# Altium schematic sheet DITEC-MEGA.SchDoc
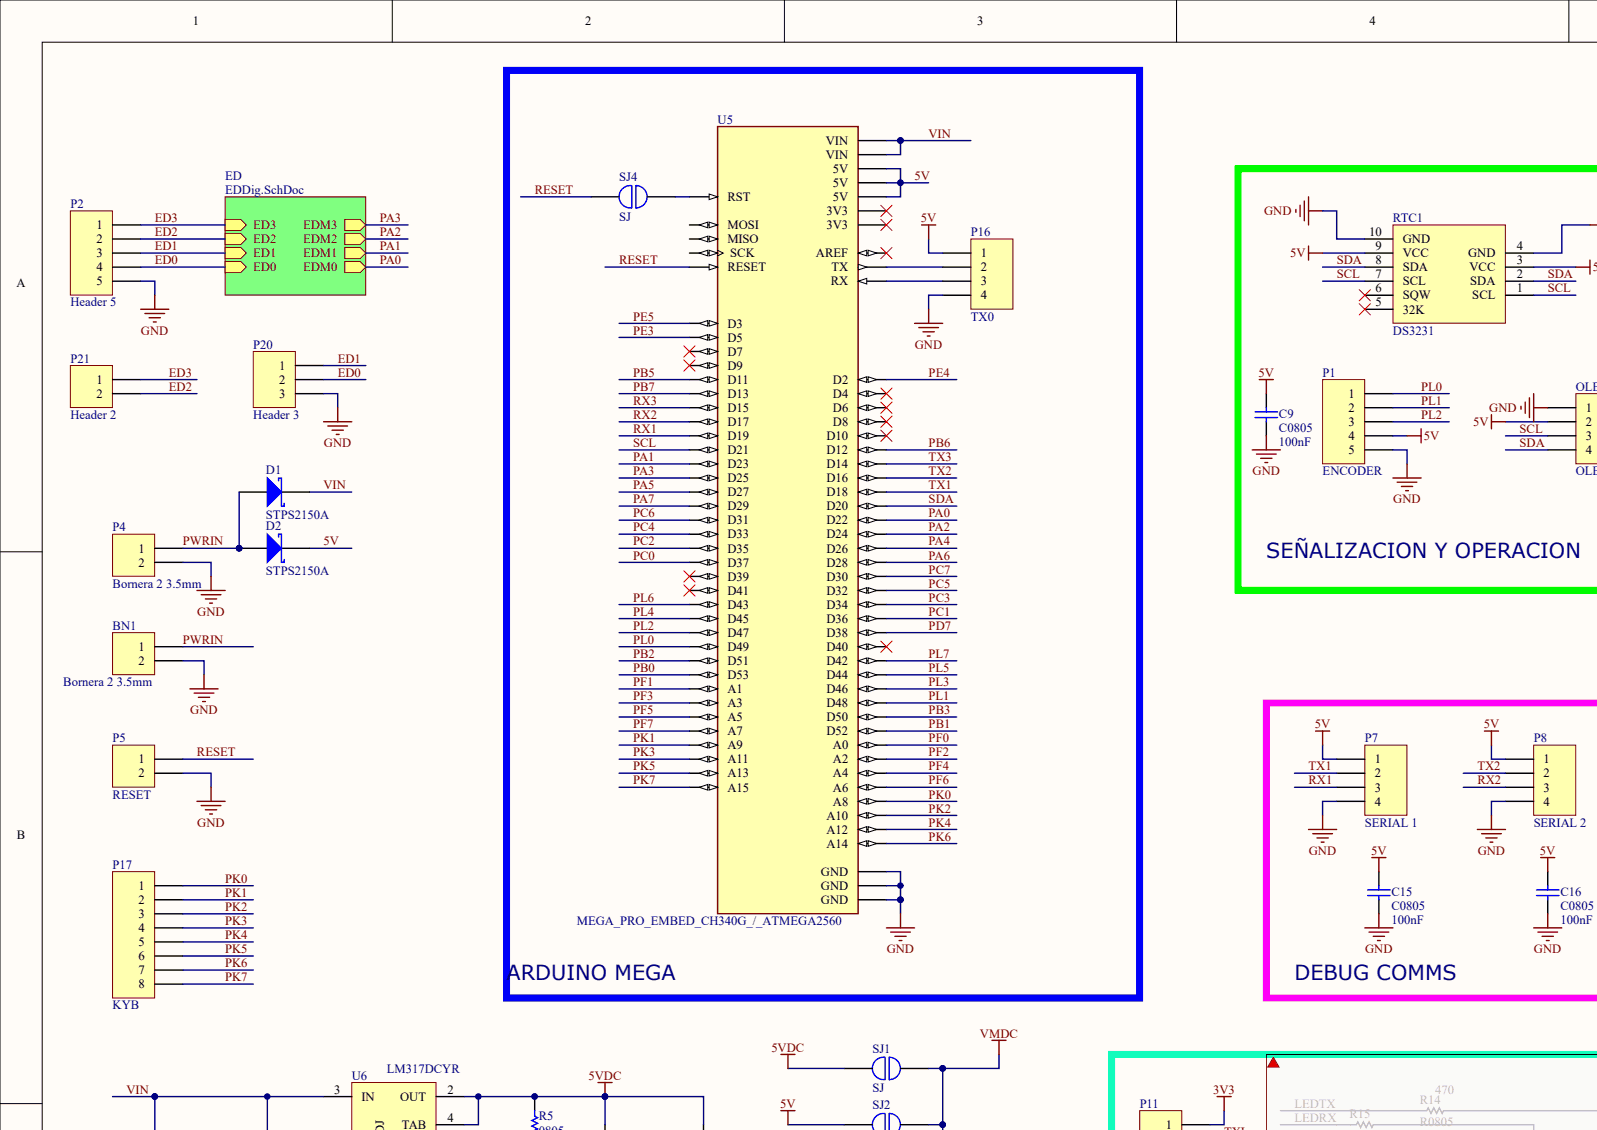
[diagram p0: DITEC-MEGA.SchDoc, top left]
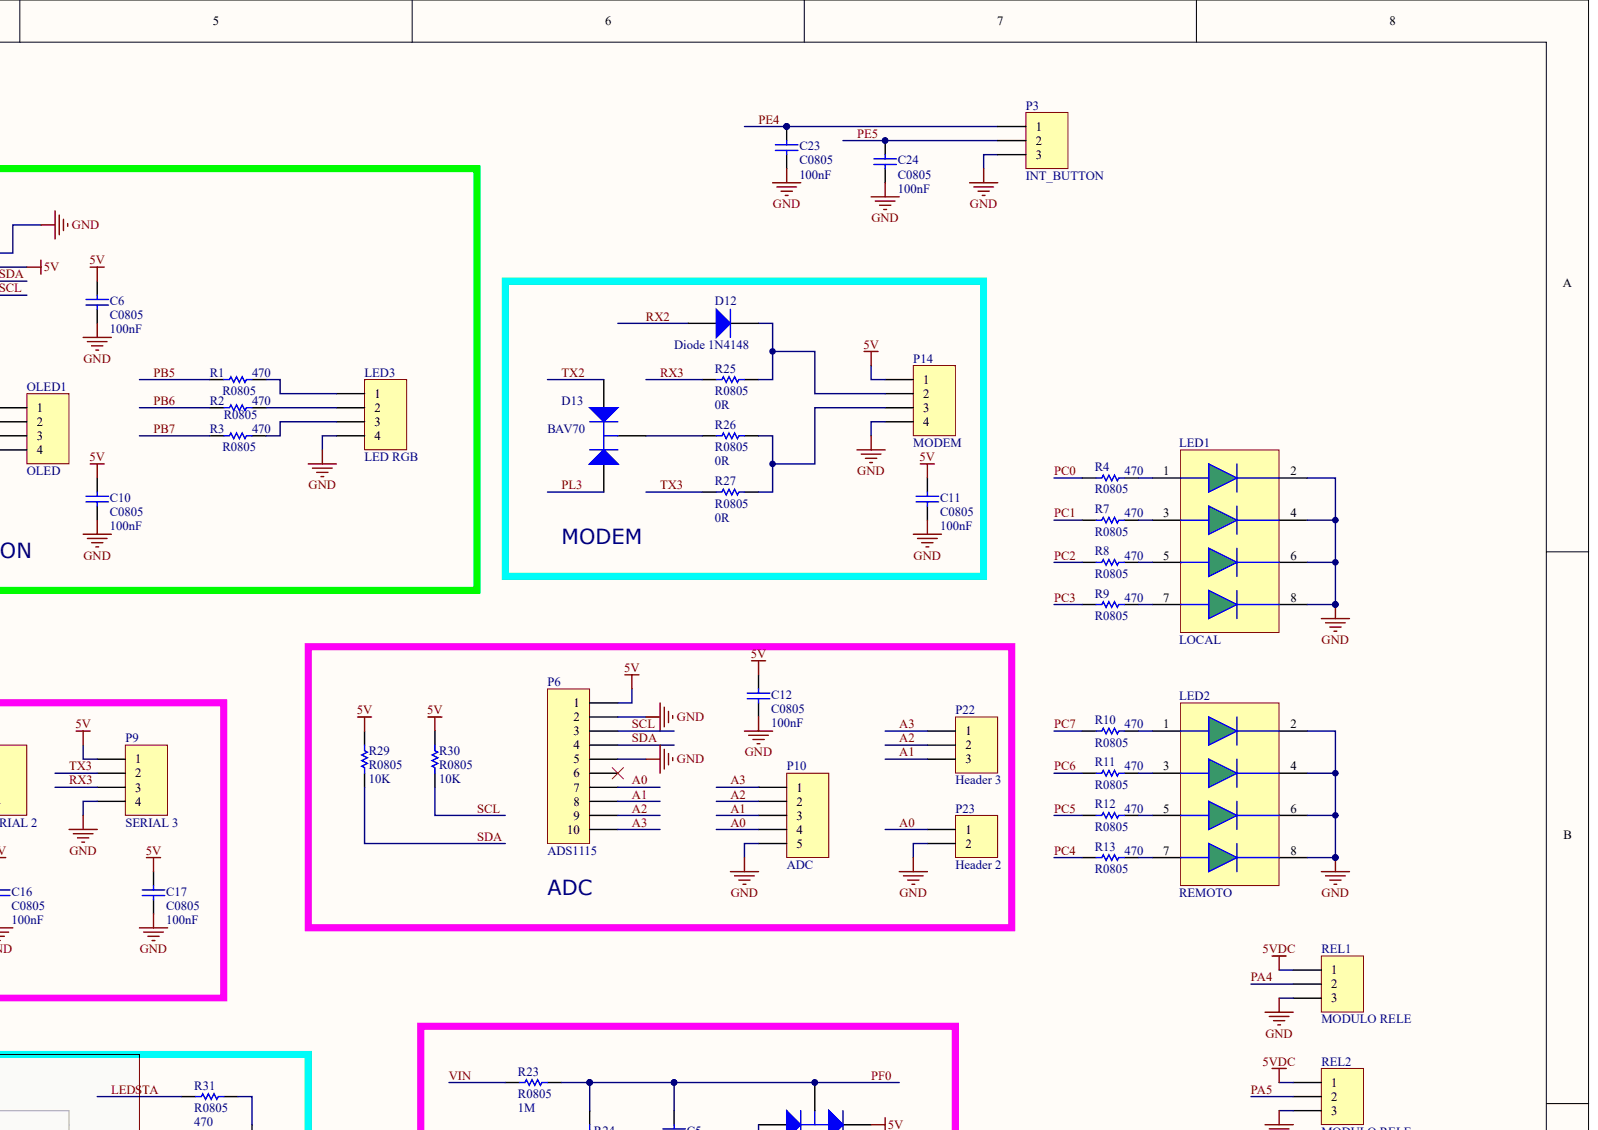
[diagram p1: DITEC-MEGA.SchDoc, top right]
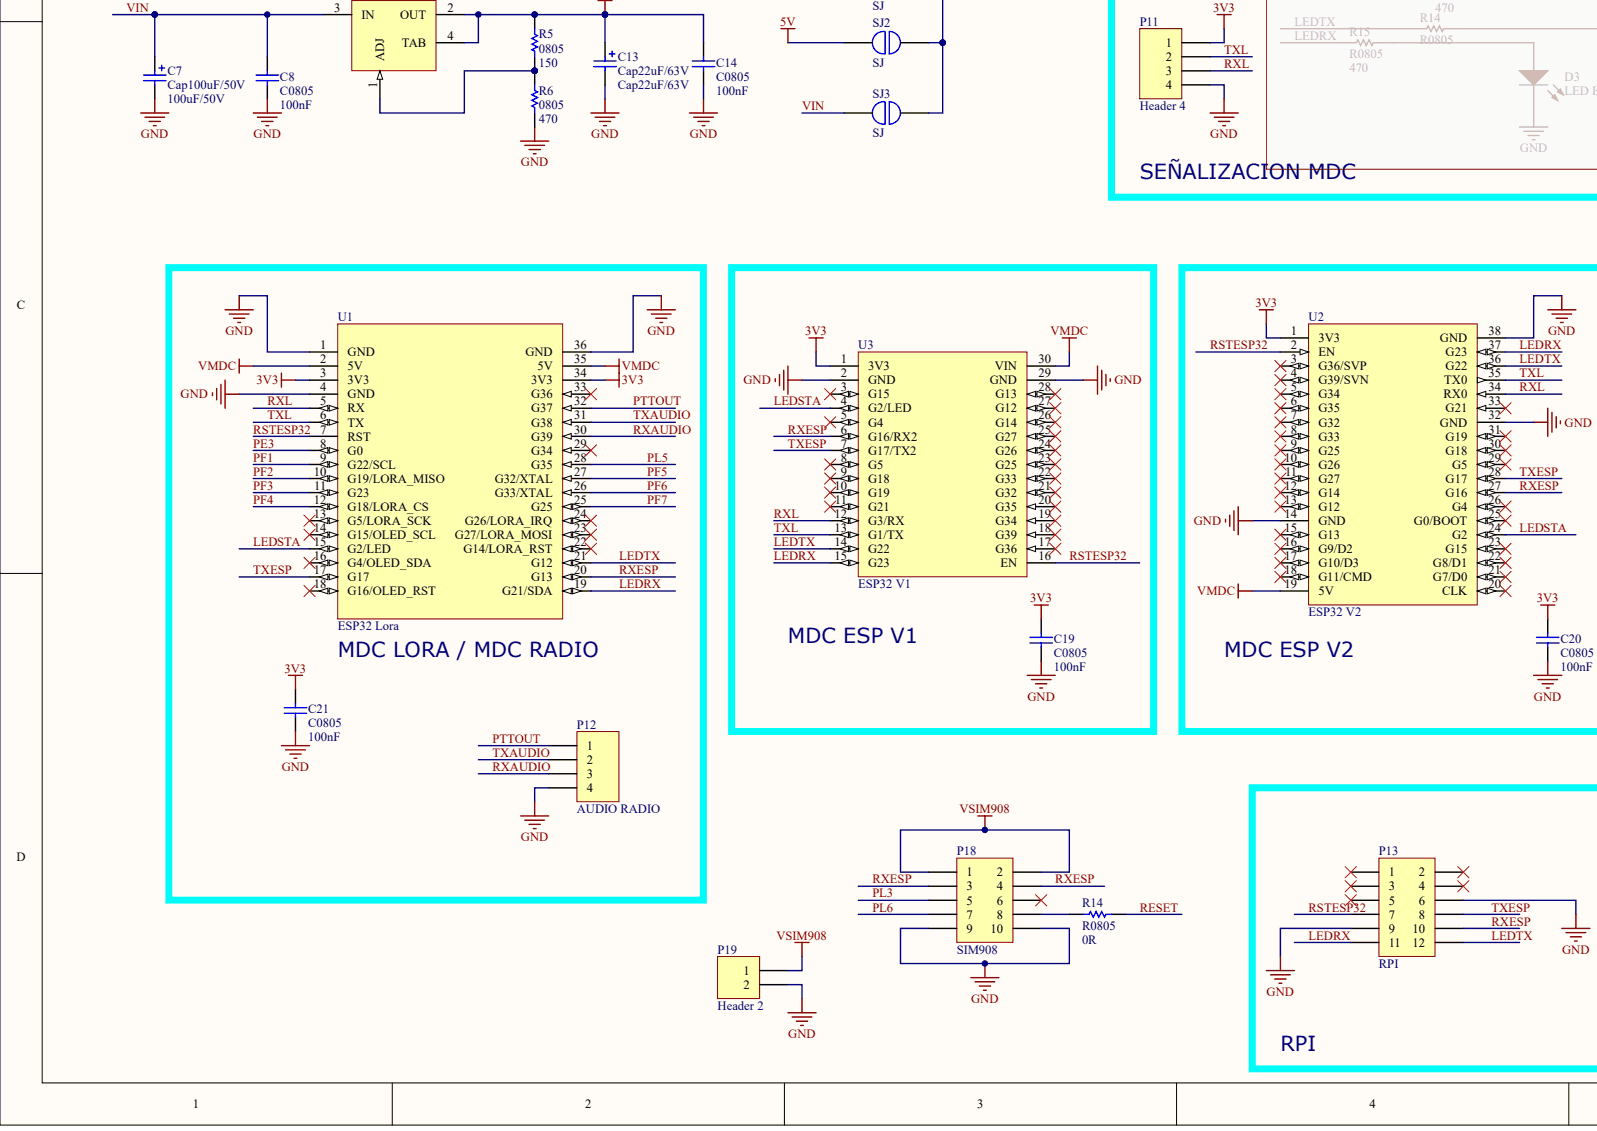
[diagram p2: DITEC-MEGA.SchDoc, bottom left]
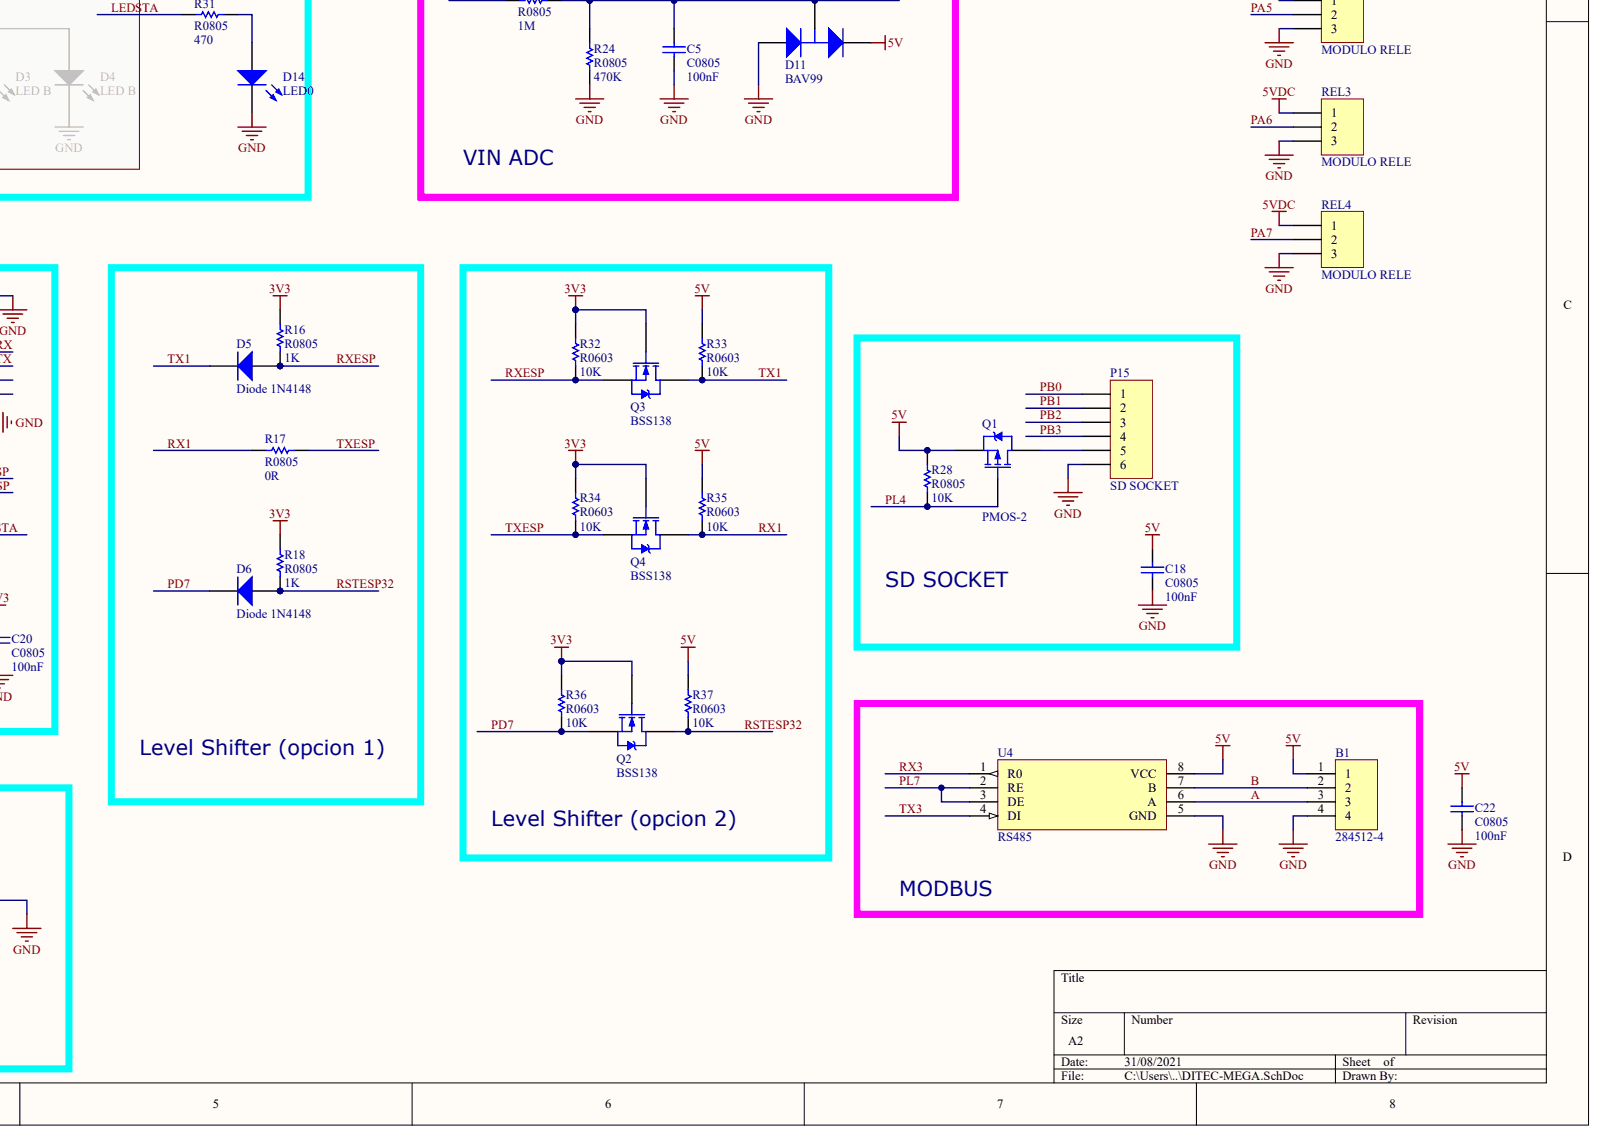
[diagram p3: DITEC-MEGA.SchDoc, bottom right]

## Parts
B1  284512-4
BN1  Bornera 2 3.5mm
C5  C0805  value 100nF
C6  C0805  value 100nF
C7  Cap100uF/50V  value 100uF/50V
C8  C0805  value 100nF
C9  C0805  value 100nF
C10  C0805  value 100nF
C11  C0805  value 100nF
C12  C0805  value 100nF
C13  Cap22uF/63V
C14  C0805  value 100nF
C15  C0805  value 100nF
C16  C0805  value 100nF
C17  C0805  value 100nF
C18  C0805  value 100nF
C19  C0805  value 100nF
C20  C0805  value 100nF
C21  C0805  value 100nF
C22  C0805  value 100nF
C23  C0805  value 100nF
C24  C0805  value 100nF
D1  STPS2150A
D2  STPS2150A
D5  Diode 1N4148
D6  Diode 1N4148
D11  BAV99
D12  Diode 1N4148
D13  BAV70
D14  LED0
LED1  LOCAL
LED2  REMOTO
LED3  LED RGB
OLED1  OLED
P1  ENCODER
P2  Header 5
P3  INT_BUTTON
P4  Bornera 2 3.5mm
P5  RESET
P6  ADS1115
P7  SERIAL 1
P8  SERIAL 2
P9  SERIAL 3
P10  ADC
P11  Header 4
P12  AUDIO RADIO
P13  RPI
P14  MODEM
P15  SD SOCKET
P16  TX0
P17  KYB
P18  SIM908
P19  Header 2
P20  Header 3
P21  Header 2
P22  Header 3
P23  Header 2
Q1  PMOS-2
Q2  BSS138
Q3  BSS138
Q4  BSS138
R1  R0805  value 470
R2  R0805  value 470
R3  R0805  value 470
R4  R0805  value 470
R5  0805  value 150
R6  0805  value 470
R7  R0805  value 470
R8  R0805  value 470
R9  R0805  value 470
R10  R0805  value 470
R11  R0805  value 470
R12  R0805  value 470
R13  R0805  value 470
R14  R0805  value 0R
R16  R0805  value 1K
R17  R0805  value 0R
R18  R0805  value 1K
R23  R0805  value 1M
R24  R0805  value 470K
R25  R0805  value 0R
R26  R0805  value 0R
R27  R0805  value 0R
R28  R0805  value 10K
R29  R0805  value 10K
R30  R0805  value 10K
R31  R0805  value 470
R32  R0603  value 10K
R33  R0603  value 10K
R34  R0603  value 10K
R35  R0603  value 10K
R36  R0603  value 10K
R37  R0603  value 10K
REL1  MODULO RELE
REL2  MODULO RELE
REL3  MODULO RELE
REL4  MODULO RELE
RTC1  DS3231
SJ1  SJ
SJ2  SJ
SJ3  SJ
SJ4  SJ
U1  ESP32 Lora
U2  ESP32 V2
U3  ESP32 V1
U4  RS485
U5  MEGA_PRO_EMBED_CH340G_/_ATMEGA2560
U6  LM317DCYR

## Nets
3V3: C19.2 C20.2 C21.2 P11.1 Q2.1 Q3.1 Q4.1 R16.2 R18.2 R32.2 R34.2 R36.2 U1.3(3V3) U1.34(3V3) U2.1(3V3) U3.1(3V3)
5VDC: C13.1 C14.2 R5.2 REL1.1 REL2.1 REL3.1 REL4.1 SJ1.1 U6.2(OUT) U6.4(TAB)
GND: B1.4 BN1.2 C5.1 C6.1 C7.2 C8.1 C9.1 C10.1 C11.1 C12.1 C13.2 C14.1 C15.1 C16.1 C17.1 C18.1 C19.1 C20.1 C21.1 C22.1 C23.1 C24.1 D11.1 D14.2 LED1.2 LED1.4 LED1.6 LED1.8 LED2.2 LED2.4 LED2.6 LED2.8 LED3.4 OLED1.1 P1.5 P2.5 P3.3 P4.2 P5.2 P6.2 P6.5 P7.4 P8.4 P9.4 P10.5 P11.4 P12.4 P13.6 P13.9 P14.4 P15.6 P16.4 P18.9 P18.10 P19.2 P20.3 P23.2 R6.1 R24.2 REL1.3 REL2.3 REL3.3 REL4.3 RTC1.4(GND) RTC1.10(GND) U1.1(GND) U1.4(GND) U1.36(GND) U2.14(GND) U2.32(GND) U2.38(GND) U3.2(GND) U3.29(GND) U4.5(GND) U5.GND_1(GND) U5.GND_2(GND) U5.GND_3(GND)
VMDC: SJ1.2 SJ2.2 SJ3.2 U1.2(5V) U1.35(5V) U2.19(5V) U3.30(VIN)
VSIM908: P18.1 P18.2 P19.1
5V: B1.1 C6.2 C9.2 C10.2 C11.2 C12.2 C15.2 C16.2 C17.2 C18.2 C22.2 D2.2 D11.2 OLED1.2 P1.4 P6.1 P7.1 P8.1 P9.1 P14.1 P16.1 Q1.3 R28.2 R29.2 R30.2 R33.2 R35.2 R37.2 RTC1.3(VCC) RTC1.9(VCC) SJ2.1 U4.8(VCC) U5.5V_1(5V) U5.5V_2(5V) U5.5V_3(5V)
A: B1.3 U4.6(A)
A0: P6.7 P10.4 P23.1
A1: P6.8 P10.3 P22.3
A2: P6.9 P10.2 P22.2
A3: P6.10 P10.1 P22.1
B: B1.2 U4.7(B)
ED0: P2.4 P20.2
ED1: P2.3 P20.1
ED2: P2.2 P21.2
ED3: P2.1 P21.1
LEDRX: P13.11 U1.19(G21/SDA) U2.37(G23) U3.15(G23)
LEDSTA: R31.1 U1.15(G2/LED) U2.24(G2) U3.4(G2/LED)
LEDTX: P13.12 U1.21(G12) U2.36(G22) U3.14(G22)
PA0: U5.D22
PA1: U5.D23
PA2: U5.D24
PA3: U5.D25
PA4: REL1.2 U5.D26
PA5: REL2.2 U5.D27
PA6: REL3.2 U5.D28
PA7: REL4.2 U5.D29
PB0: P15.1 U5.D53
PB1: P15.2 U5.D52
PB2: P15.3 U5.D51
PB3: P15.4 U5.D50
PB5: R1.1 U5.D11
PB6: R2.1 U5.D12
PB7: R3.1 U5.D13
PC0: R4.1 U5.D37
PC1: R7.1 U5.D36
PC2: R8.1 U5.D35
PC3: R9.1 U5.D34
PC4: R13.1 U5.D33
PC5: R12.1 U5.D32
PC6: R11.1 U5.D31
PC7: R10.1 U5.D30
PD7: D6.2 Q2.3 R36.1 U5.D38
PE3: U1.8(G0) U5.D5
PE4: C23.2 P3.1 U5.D2
PE5: C24.2 P3.2 U5.D3
PF0: C5.2 D11.3 R23.2 R24.1 U5.A0
PF1: U1.9(G22/SCL) U5.A1
PF2: U1.10(G19/LORA_MISO) U5.A2
PF3: U1.11(G23) U5.A3
PF4: U1.12(G18/LORA_CS) U5.A4
PF5: U1.27(G32/XTAL) U5.A5
PF6: U1.26(G33/XTAL) U5.A6
PF7: U1.25(G25) U5.A7
PK0: P17.1 U5.A8
PK1: P17.2 U5.A9
PK2: P17.3 U5.A10
PK3: P17.4 U5.A11
PK4: P17.5 U5.A12
PK5: P17.6 U5.A13
PK6: P17.7 U5.A14
PK7: P17.8 U5.A15
PL0: P1.1 U5.D49
PL1: P1.2 U5.D48
PL2: P1.3 U5.D47
PL3: D13.2 P18.5 U5.D46
PL4: Q1.1 R28.1 U5.D45
PL5: U1.28(G35) U5.D44
PL6: P18.7 U5.D43
PL7: U4.2(RE) U4.3(DE) U5.D42
PTTOUT: P12.1 U1.32(G37)
PWRIN: BN1.1 D1.1 D2.1 P4.1
RESET: P5.1 R14.2 SJ4.1 U5.RESET
RSTESP32: D6.1 P13.7 Q2.2 R18.1 R37.1 U1.7(RST) U2.2(EN) U3.16(EN)
RX1: P7.3 Q4.2 R17.1 R35.1 U5.D19
RX2: D12.1 P8.3 U5.D17
RX3: P9.3 R25.1 U4.1(R0) U5.D15
RXAUDIO: P12.3 U1.30(G39)
RXESP: D5.1 P13.10 P18.3 P18.4 Q3.3 R16.1 R32.1 U1.20(G13) U2.27(G16) U3.6(G16/RX2)
RXL: P11.3 U1.5(RX) U2.34(RX0) U3.12(G3/RX)
SCL: OLED1.3 P6.3 R30.1 RTC1.1(SCL) RTC1.7(SCL) U5.D21
SDA: OLED1.4 P6.4 R29.1 RTC1.2(SDA) RTC1.8(SDA) U5.D20
TX1: D5.2 P7.2 Q3.2 R33.1 U5.D18
TX2: D13.1 P8.2 U5.D16
TX3: P9.2 R27.1 U4.4(DI) U5.D14
TXAUDIO: P12.2 U1.31(G38)
TXESP: P13.8 Q4.3 R17.2 R34.1 U1.17(G17) U2.28(G17) U3.7(G17/TX2)
TXL: P11.2 U1.6(TX) U2.35(TX0) U3.13(G1/TX)
VIN: C7.1 C8.2 D1.2 R23.1 SJ3.1 U5.VIN_1(VIN) U5.VIN_2(VIN) U6.3(IN)
NetD12_2: D12.2 P14.2 R25.2
NetD13_3: D13.3 R26.1
NetD14_1: D14.1 R31.2
NetLED1_1: LED1.1 R4.2
NetLED1_3: LED1.3 R7.2
NetLED1_5: LED1.5 R8.2
NetLED1_7: LED1.7 R9.2
NetLED2_1: LED2.1 R10.2
NetLED2_3: LED2.3 R11.2
NetLED2_5: LED2.5 R12.2
NetLED2_7: LED2.7 R13.2
NetLED3_1: LED3.1 R1.2
NetLED3_2: LED3.2 R2.2
NetLED3_3: LED3.3 R3.2
NetP6_6: P6.6
NetP13_1: P13.1
NetP13_2: P13.2
NetP13_3: P13.3
NetP13_4: P13.4
NetP13_5: P13.5
NetP14_3: P14.3 R26.2 R27.2
NetP15_5: P15.5 Q1.2
NetP16_2: P16.2 U5.TX
NetP16_3: P16.3 U5.RX
NetP18_6: P18.6
NetP18_8: P18.8 R14.1
NetR5_1: R5.1 R6.2 U6.1(ADJ)
NetRTC1_5: RTC1.5(32K)
NetRTC1_6: RTC1.6(SQW)
NetSJ4_2: SJ4.2 U5.RST
NetU1_13: U1.13(G5/LORA_SCK)
NetU1_14: U1.14(G15/OLED_SCL)
NetU1_16: U1.16(G4/OLED_SDA)
NetU1_18: U1.18(G16/OLED_RST)
NetU1_22: U1.22(G14/LORA_RST)
NetU1_23: U1.23(G27/LORA_MOSI)
NetU1_24: U1.24(G26/LORA_IRQ)
NetU1_29: U1.29(G34)
NetU1_33: U1.33(G36)
NetU2_3: U2.3(G36/SVP)
NetU2_4: U2.4(G39/SVN)
NetU2_5: U2.5(G34)
NetU2_6: U2.6(G35)
NetU2_7: U2.7(G32)
NetU2_8: U2.8(G33)
NetU2_9: U2.9(G25)
NetU2_10: U2.10(G26)
NetU2_11: U2.11(G27)
NetU2_12: U2.12(G14)
NetU2_13: U2.13(G12)
NetU2_15: U2.15(G13)
NetU2_16: U2.16(G9/D2)
NetU2_17: U2.17(G10/D3)
NetU2_18: U2.18(G11/CMD)
NetU2_20: U2.20(CLK)
NetU2_21: U2.21(G7/D0)
NetU2_22: U2.22(G8/D1)
NetU2_23: U2.23(G15)
NetU2_25: U2.25(G0/BOOT)
NetU2_26: U2.26(G4)
NetU2_29: U2.29(G5)
NetU2_30: U2.30(G18)
NetU2_31: U2.31(G19)
NetU2_33: U2.33(G21)
NetU3_3: U3.3(G15)
NetU3_5: U3.5(G4)
NetU3_8: U3.8(G5)
NetU3_9: U3.9(G18)
NetU3_10: U3.10(G19)
NetU3_11: U3.11(G21)
NetU3_17: U3.17(G36)
NetU3_18: U3.18(G39)
NetU3_19: U3.19(G34)
NetU3_20: U3.20(G35)
NetU3_21: U3.21(G32)
NetU3_22: U3.22(G33)
NetU3_23: U3.23(G25)
NetU3_24: U3.24(G26)
NetU3_25: U3.25(G27)
NetU3_26: U3.26(G14)
NetU3_27: U3.27(G12)
NetU3_28: U3.28(G13)
NetU5_3V3_1: U5.3V3_1(3V3)
NetU5_3V3_2: U5.3V3_2(3V3)
NetU5_AREF: U5.AREF
NetU5_D4: U5.D4
NetU5_D6: U5.D6
NetU5_D7: U5.D7
NetU5_D8: U5.D8
NetU5_D9: U5.D9
NetU5_D10: U5.D10
NetU5_D39: U5.D39
NetU5_D40: U5.D40
NetU5_D41: U5.D41
NetU5_MISO: U5.MISO
NetU5_MOSI: U5.MOSI
NetU5_SCK: U5.SCK

## Library data of the parts
B1 = (no symbol name): Part: A98398-ND; Proveedor: Digikey; LatestRevisionDate: 17-Jul-2002; LatestRevisionNote: Re-released for DXP Platform.; : 0.64/125; Price: 0.15; Publisher: Altium Limited
BN1 P4 = (no symbol name): Part: A98396-ND; Proveedor: Digikey; LatestRevisionDate: 17-Jul-2002; LatestRevisionNote: Re-released for DXP Platform.; Price: 0.08; Publisher: Altium Limited
C5 C6 C8-C12 C14-C24 = (no symbol name): Part: 399-1170-1-ND; Proveedor: Digikey; Published: 8-Jun-2000; LatestRevisionDate: 17-Jul-2002; LatestRevisionNote: Re-released for DXP Platform.; PackageReference: C1206; Price: 0.10; Publisher: Altium Limited
C7 = (no symbol name): Part: P5182-ND; Published: 8-Jun-2000; LatestRevisionDate: 17-Jul-2002; LatestRevisionNote: Re-released for DXP Platform.; PackageReference: RB7.6-15; Price: 0.33; Publisher: Altium Limited
C13 = (no symbol name): Part: P5190-ND; Proveedor: Digikey; Published: 8-Jun-2000; LatestRevisionDate: 17-Jul-2002; LatestRevisionNote: Re-released for DXP Platform.; PackageReference: RB7.6-15; Price: 0.22/1;0.092/50;0.078/100; Value: Cap22uF/63V; Publisher: Altium Limited
D1 D2 = (no symbol name): Part: 497-2467-1-ND; Proveedor: Digikey; Published: 8-Jun-2000; LatestRevisionDate: 17-Jul-2002; LatestRevisionNote: Re-released for DXP Platform.; DatasheetVersion: Dec-1997; PackageReference: DO-204AL; Code_JEDEC: DO-204-AL; ComponentLink1Description: Manufacturer Link; ComponentLink1URL: http://www.irf.com/; ComponentLink2Description: Datasheet; ComponentLink2URL: http://www.irf.com/product-info/datasheets/data/11dq03.pdf; Price: 0.49; Publisher: Altium Limited
D5 D6 D12 = (no symbol name): Part: 1N4148WTPMSCT; Proveedor: Digikey; Published: 8-Jun-2000; LatestRevisionDate: 17-Jul-2002; LatestRevisionNote: Re-released for DXP Platform.; PackageVersion: Sep-1996; PackageReference: SMC; Code_JEDEC: DO-214-AB; Price: 0.14; Publisher: Altium Limited
Q1 = (no symbol name): Note: Pin 4 not drawn and electrically connected to Pin 2.; Published: 8-Jun-2000; LatestRevisionDate: 17-Jul-2002; LatestRevisionNote: Re-released for DXP Platform.; DatasheetDocument: PD-9.390D; PackageReference: TO-220AB; Code_JEDEC: TO-220-AB; ComponentLink1Description: Manufacturer Link; ComponentLink1URL: http://www.irf.com/; Publisher: Altium Limited
Q2-Q4 = (no symbol name): Part: FDN357NCT-ND; Note: Pin 2 is electrically connected to pin 4.; Proveedor: Digikey; Published: 8-Jun-2000; LatestRevisionDate: 17-Jul-2002; LatestRevisionNote: Re-released for DXP Platform.; DatasheetDocument: PD-9.814; PackageReference: TO-220AB; Code_JEDEC: TO-220-AB; ComponentLink1Description: Manufacturer Link; ComponentLink1URL: http://www.irf.com/; Price: 0.56; Publisher: Altium Limited
R1-R4 R7-R13 R31 = (no symbol name): Part: 311-470ARCT-ND; Proveedor: Digikey; Published: 8-Jun-2000; LatestRevisionDate: 17-Jul-2002; LatestRevisionNote: Re-released for DXP Platform.; PackageReference: AXIAL-0.5; Price: 0.1; Publisher: Altium Limited
R5 = (no symbol name): Part: 541-100CCT-ND; Proveedor: Digikey; Published: 8-Jun-2000; LatestRevisionDate: 17-Jul-2002; LatestRevisionNote: Re-released for DXP Platform.; PackageReference: AXIAL-0.5; Price: 0.089; Publisher: Altium Limited
R6 = (no symbol name): Part: 541-390CCT-ND; Proveedor: Digikey; Published: 8-Jun-2000; LatestRevisionDate: 17-Jul-2002; LatestRevisionNote: Re-released for DXP Platform.; PackageReference: AXIAL-0.5; Price: 0.077; Publisher: Altium Limited
R14 R17 R26 R27 = (no symbol name): Part: 311-0.0ARCT-ND; Proveedor: Digikey; Published: 8-Jun-2000; LatestRevisionDate: 17-Jul-2002; LatestRevisionNote: Re-released for DXP Platform.; PackageReference: AXIAL-0.5; Price: 0.1; Publisher: Altium Limited
R16 R18 = (no symbol name): Part: 311-1.0KARCT-ND; Proveedor: Digikey; Published: 8-Jun-2000; LatestRevisionDate: 17-Jul-2002; LatestRevisionNote: Re-released for DXP Platform.; PackageReference: AXIAL-0.5; Price: 0.1; Publisher: Altium Limited
R23 = (no symbol name): Part: 311-1.0MARCT-ND; Proveedor: Digikey; Published: 8-Jun-2000; LatestRevisionDate: 17-Jul-2002; LatestRevisionNote: Re-released for DXP Platform.; PackageReference: AXIAL-0.5; Price: 0.1; Publisher: Altium Limited
R24 = (no symbol name): Part: 311-470KARCT-ND; Proveedor: Digikey; Published: 8-Jun-2000; LatestRevisionDate: 17-Jul-2002; LatestRevisionNote: Re-released for DXP Platform.; PackageReference: AXIAL-0.5; Price: 0.1; Publisher: Altium Limited
R25 = (no symbol name): Part: 311-0.0ARCT-ND; Proveedor: Digikey; Value: 0R; Published: 8-Jun-2000; LatestRevisionDate: 17-Jul-2002; LatestRevisionNote: Re-released for DXP Platform.; PackageReference: AXIAL-0.5; Price: 0.1; Publisher: Altium Limited
R28-R30 = (no symbol name): Part: 311-10KARCT-ND; Proveedor: Digikey; Published: 8-Jun-2000; LatestRevisionDate: 17-Jul-2002; LatestRevisionNote: Re-released for DXP Platform.; PackageReference: AXIAL-0.5; Price: 0.1; Publisher: Altium Limited
U5 = (no symbol name): MP: Mega Pro Embed CH340G / ATmega2560; MF: Robotdyn; Package: Package; Price: None; Availability: Unavailable; Value: *; SnapEDA_Link: https://www.snapeda.com/parts/Mega%20Pro%20Embed%20CH340G%20/%20ATmega2560/Robotdyn/view-part/4052597/?ref=snap; BuyPart: https://www.snapeda.com/parts/Mega%20Pro%20Embed%20CH340G%20/%20ATmega2560/Robotdyn/view-part/4052597/?ref=eda
U6 = (no symbol name): PartNumber: LM317EMP; Manufacturer: National Semiconductor; Part: 296-12602-1-ND; CompType: LDO IC; PackageTopMarking: XYTT*N01A; PowerWise: N; Proveedor: Digikey; Published: 4-Dec-2008; DatasheetVersion: Apr-2007; PackageVersion: revB, Apr-2003; PackageReference: MP04A; PackageDescription: Molded SOT-223, 6.50 x 3.56 x 1.6mm Body, 4 Lead, 2.3mm Pitch; RoHS: O; PinCount: 4; DigiKeyPartNumber: LM317EMPCT-ND; Code_JEDEC: TO-261-AA; Code_IPC: SOT223-4; ComponentLink1Description: LM317 Datasheet; ComponentLink1URL: http://www.national.com/ds/LM/LM317.pdf; ComponentLink2Description: LM317 Web Page; ComponentLink2URL: http://www.national.com/pf/LM/LM317.html; ComponentLink3Description: MP04A Package Page; ComponentLink3URL: http://www.national.com/packaging/folders/mp04a.html; Price: 0.66; Publisher: Altium Limited
D11 D13 D14 LED1-LED3 OLED1 P1-P3 P5-P23 R32-R37 REL1-REL4 RTC1 SJ1-SJ4 U1-U4 = (no symbol name)
  D11: Published: 21-Mar-2003; DatasheetDocument: Oct-1999; PackageDocument: Sep-2001; PackageReference: SOT-23; ComponentLink1Description: Manufacturer Link; ComponentLink1URL: http://www.infineon.com/; ComponentLink2Description: Datasheet; ComponentLink2URL: http://www.infineon.com/upload/Document/cmc_upload/documents/080/631/bav99series_1.pdf
  D13: Published: 21-Mar-2003; DatasheetDocument: Oct-1999; PackageDocument: Sep-2001; PackageReference: SOT-23; ComponentLink1Description: Manufacturer Link; ComponentLink1URL: http://www.infineon.com/; ComponentLink2Description: Datasheet; ComponentLink2URL: http://www.infineon.com/upload/Document/cmc_upload/documents/080/865/bav70series.pdf
  D14: Published: 8-Jun-2000; LatestRevisionDate: 08-Jul-2005; LatestRevisionNote: Mech Layer 1 removed.; PackageReference: LED-0; PackageDescription: LED; 2 Leads
  LED1 LED2: : *
  LED3 OLED1 P1-P3 P5-P23 REL1-REL4: LatestRevisionDate: 17-Jul-2002; LatestRevisionNote: Re-released for DXP Platform.
  R32-R37: Published: 8-Jun-2000; LatestRevisionDate: 17-Jul-2002; LatestRevisionNote: Re-released for DXP Platform.; PackageReference: AXIAL-0.5; Price: 0.1
  RTC1: Price: 6
  U4: FirstPublished: 29-Jan-2009; DatasheetVersion: revF, Oct-2009; PackageVersion: revBB, Aug-2009; PackageReference: SOIC-SN8; PackageDescription: 8-Lead Plastic Small Outline (SN) - Narrow, 3.90mm Body [SOIC]; Supplier 1: Digi-Key; Supplier 2: Farnell; Supplier Part Number 2: 1331314; Supplier 3: Newark; Supplier Part Number 3: 72C4393; Code_JEDEC: MS-012; Code_IPC: SOIC127P600-8; ComponentLink1Description: Datasheet; ComponentLink2Description: Packaging Specification; ComponentLink3Description: Microchip.com Home
  D11 D13 D14 LED3 OLED1 P1-P3 P5-P23 R32-R37 REL1-REL4 U4: Publisher: Altium Limited
